# Altium schematic sheet 1.2.0_XBee_module.SchDoc
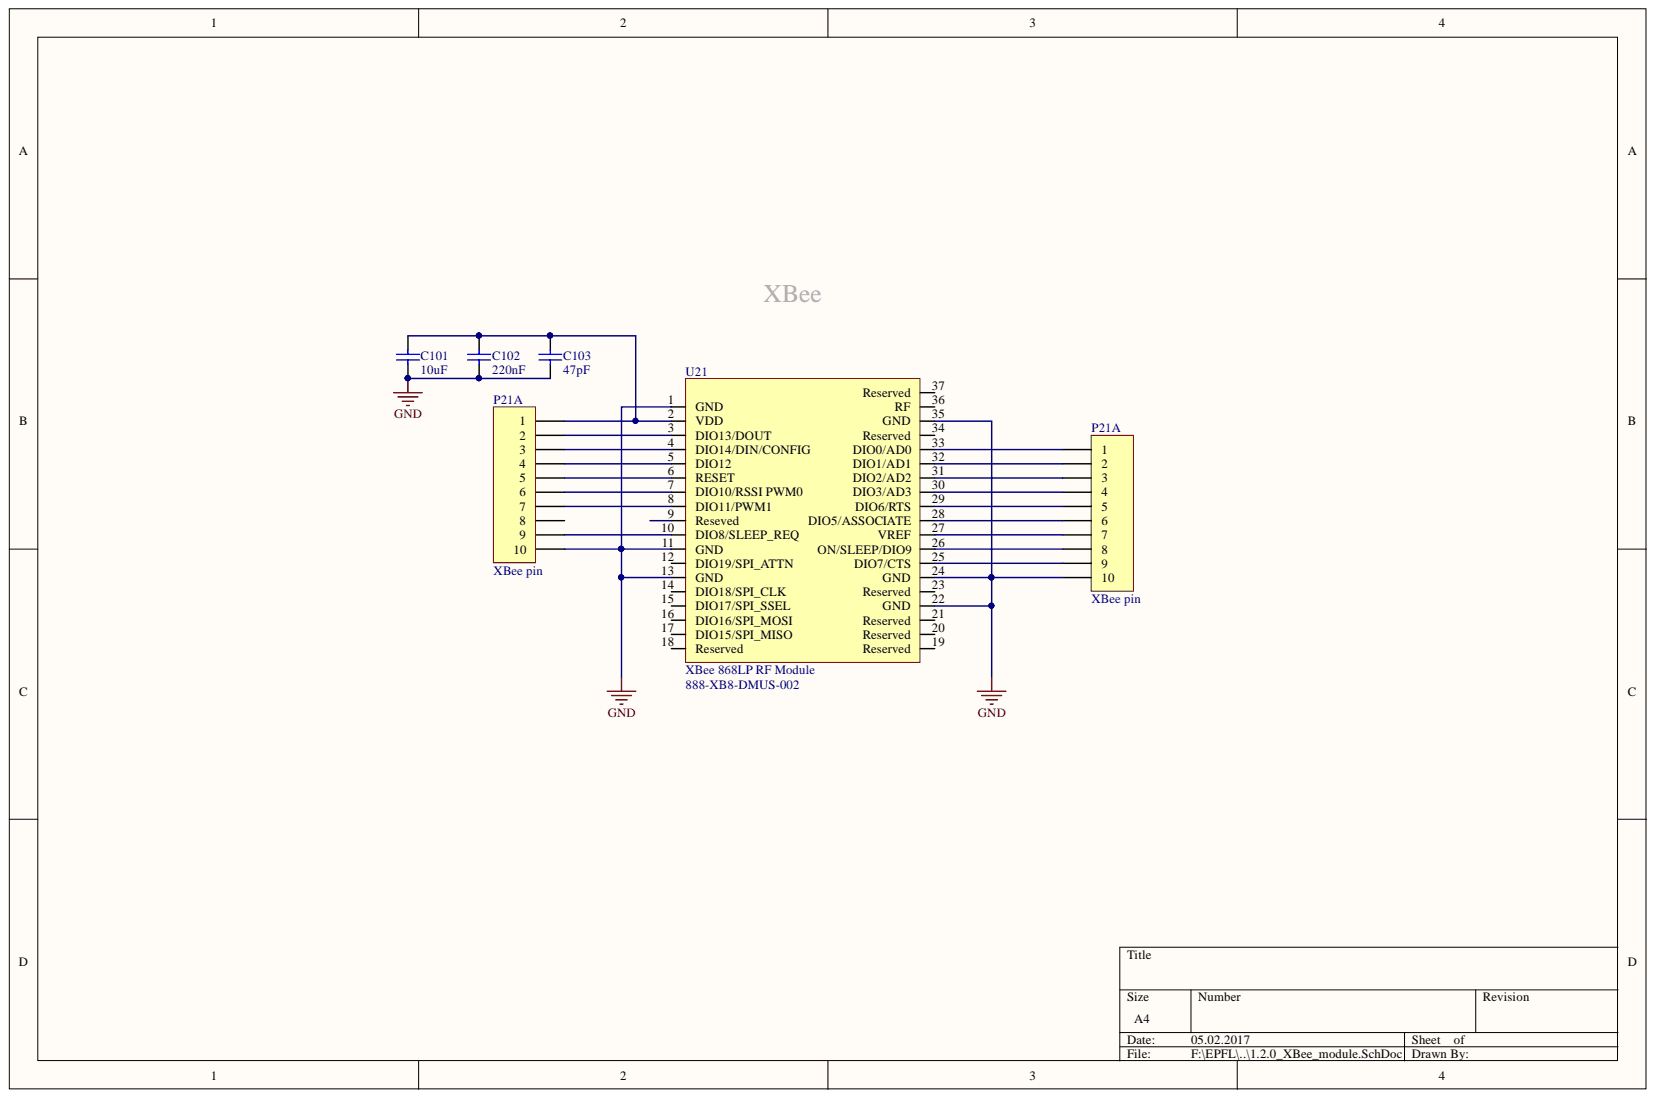
[diagram p0: 1.2.0_XBee_module.SchDoc]

## Parts
C101  10uF  [CAP0805W]
C102  220nF  [CAP0603R]
C103  47pF  [CAP0603R]
P21  XBee pin  [XBEE PIN]  (part A)
P21  XBee pin  [XBEE PIN]  (part A)
U21  XBee 868LP RF Module  Supplier Part Number 1: 888-XB8-DMUS-002  [XBee 868LP RF Module]

## Nets
GND: C101.1 C102.1 C103.1 P21.10 U21.1(GND) U21.11(GND) U21.13(GND) U21.22(GND) U21.24(GND) U21.35(GND)
NetC101_2: C101.2 C102.2 C103.2 P21.1 U21.2(VDD)
NetP21_1: P21.1 U21.33(DIO0/AD0)
NetP21_2: P21.2 U21.3(DIO13/DOUT) U21.32(DIO1/AD1)
NetP21_3: P21.3 U21.4(DIO14/DIN/CONFIG) U21.31(DIO2/AD2)
NetP21_4: P21.4 U21.5(DIO12) U21.30(DIO3/AD3)
NetP21_5: P21.5 U21.6(RESET) U21.29(DIO6/RTS)
NetP21_6: P21.6 U21.7(DIO10/RSSI_PWM0) U21.28(DIO5/ASSOCIATE)
NetP21_7: P21.7 U21.8(DIO11/PWM1) U21.27(VREF)
NetP21_8: P21.8 U21.26(ON/SLEEP/DIO9)
NetP21_9: P21.9 U21.10(DIO8/SLEEP_REQ) U21.25(DIO7/CTS)

Not connected: U21 9(Reseved) 12(DIO19/SPI_ATTN) 14(DIO18/SPI_CLK) 15(DIO17/SPI_SSEL) 16(DIO16/SPI_MOSI) 17(DIO15/SPI_MISO) 18(Reserved) 19(Reserved) 20(Reserved) 21(Reserved) 23(Reserved) 34(Reserved) 36 37(Reserved)

## Library data of the parts
C101 = C_10uF_16v: Capacitor; Component Kind: Standard; Supplier 1: Mouser; Supplier Part Number 1: 81-GRT21BC81C106ME1L; Library Name: Rocket_library.SchLib
C102 = C_220nF: Capacitor; Component Kind: Standard; Signal Integrity: Cap; Simulation: CAP; Supplier 1: Mouser; Supplier Part Number 1: 81-GCG188R91H224KA1D; Library Name: Rocket_library.SchLib
C103 = C_47pF_25V: Capacitor; Component Kind: Standard; Supplier 1: Mouser; Supplier Part Number 1: 77-VJ0603A470JXXPBC; Library Name: Rocket_library.SchLib
P21 P21 = XBee pin: Component Kind: Standard; Description: XBee pin; Library Name: Rocket_library.SchLib
U21 = XBee 868LP RF Module: Component Kind: Standard; Description: XBee 868LP RF Module; Supplier 1: Mouser; Library Name: Rocket_library.SchLib
